# Altium schematic sheet led_clock-LEDDRV.SchDoc
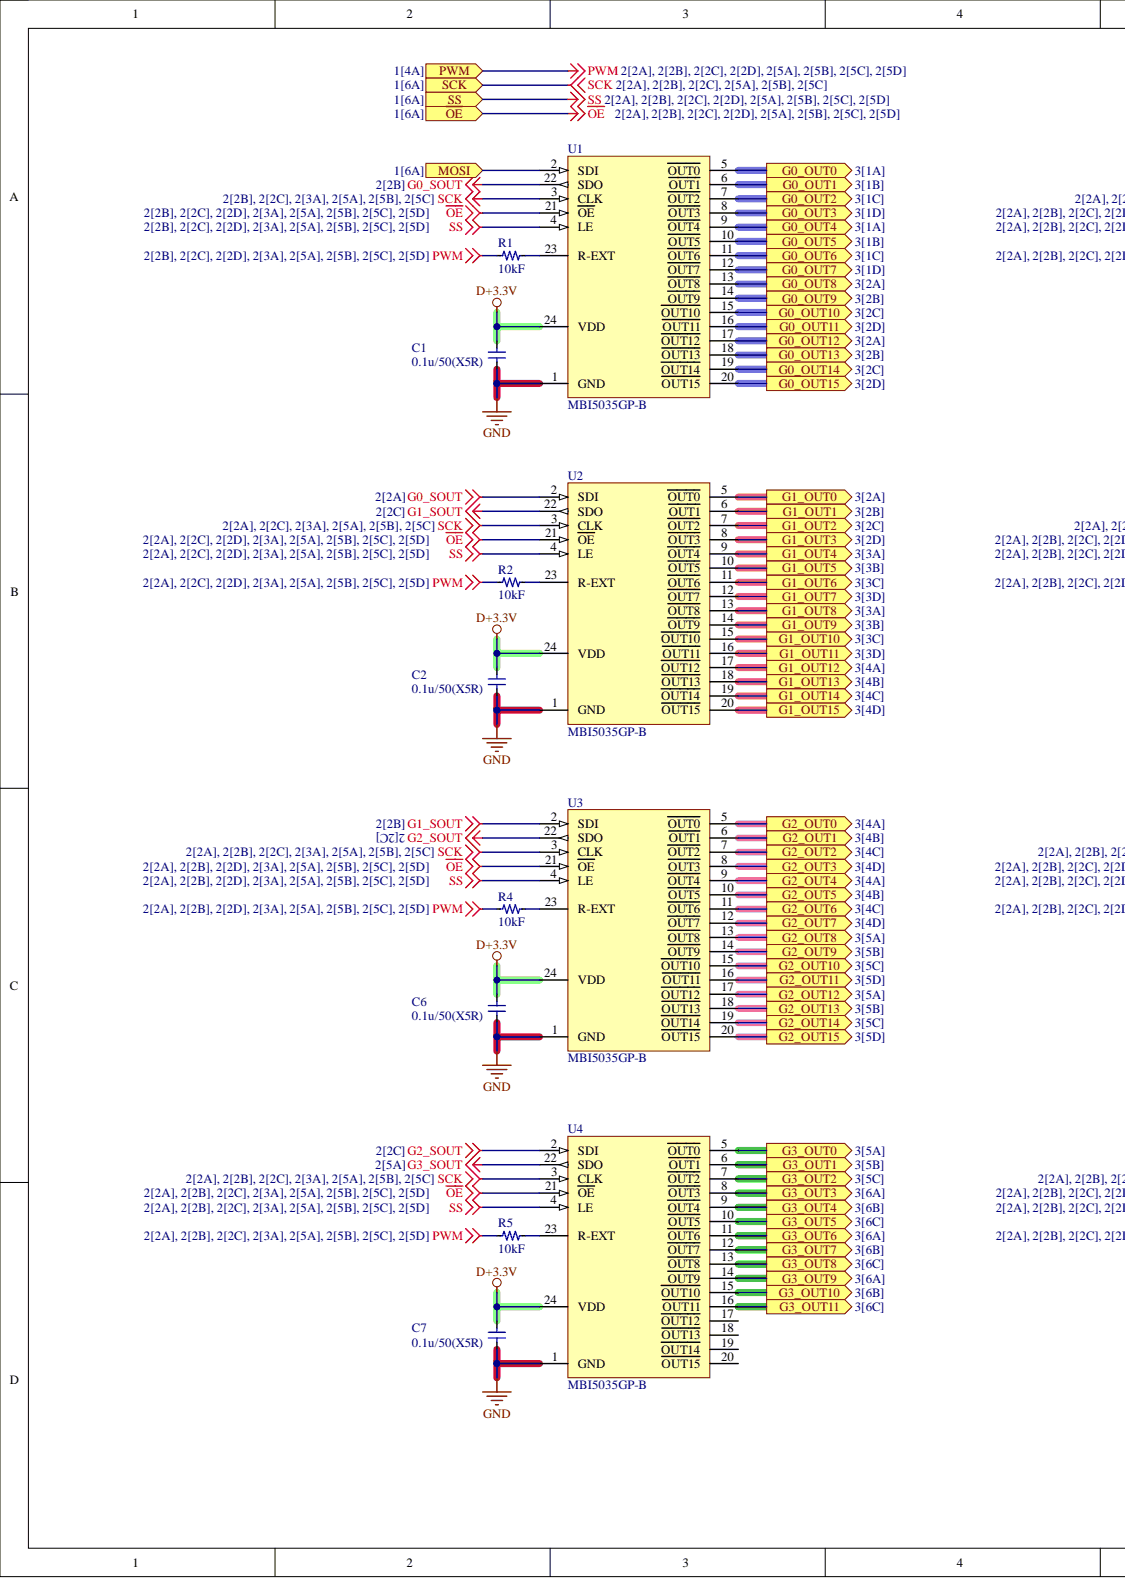
[diagram p0: led_clock-LEDDRV.SchDoc, left]
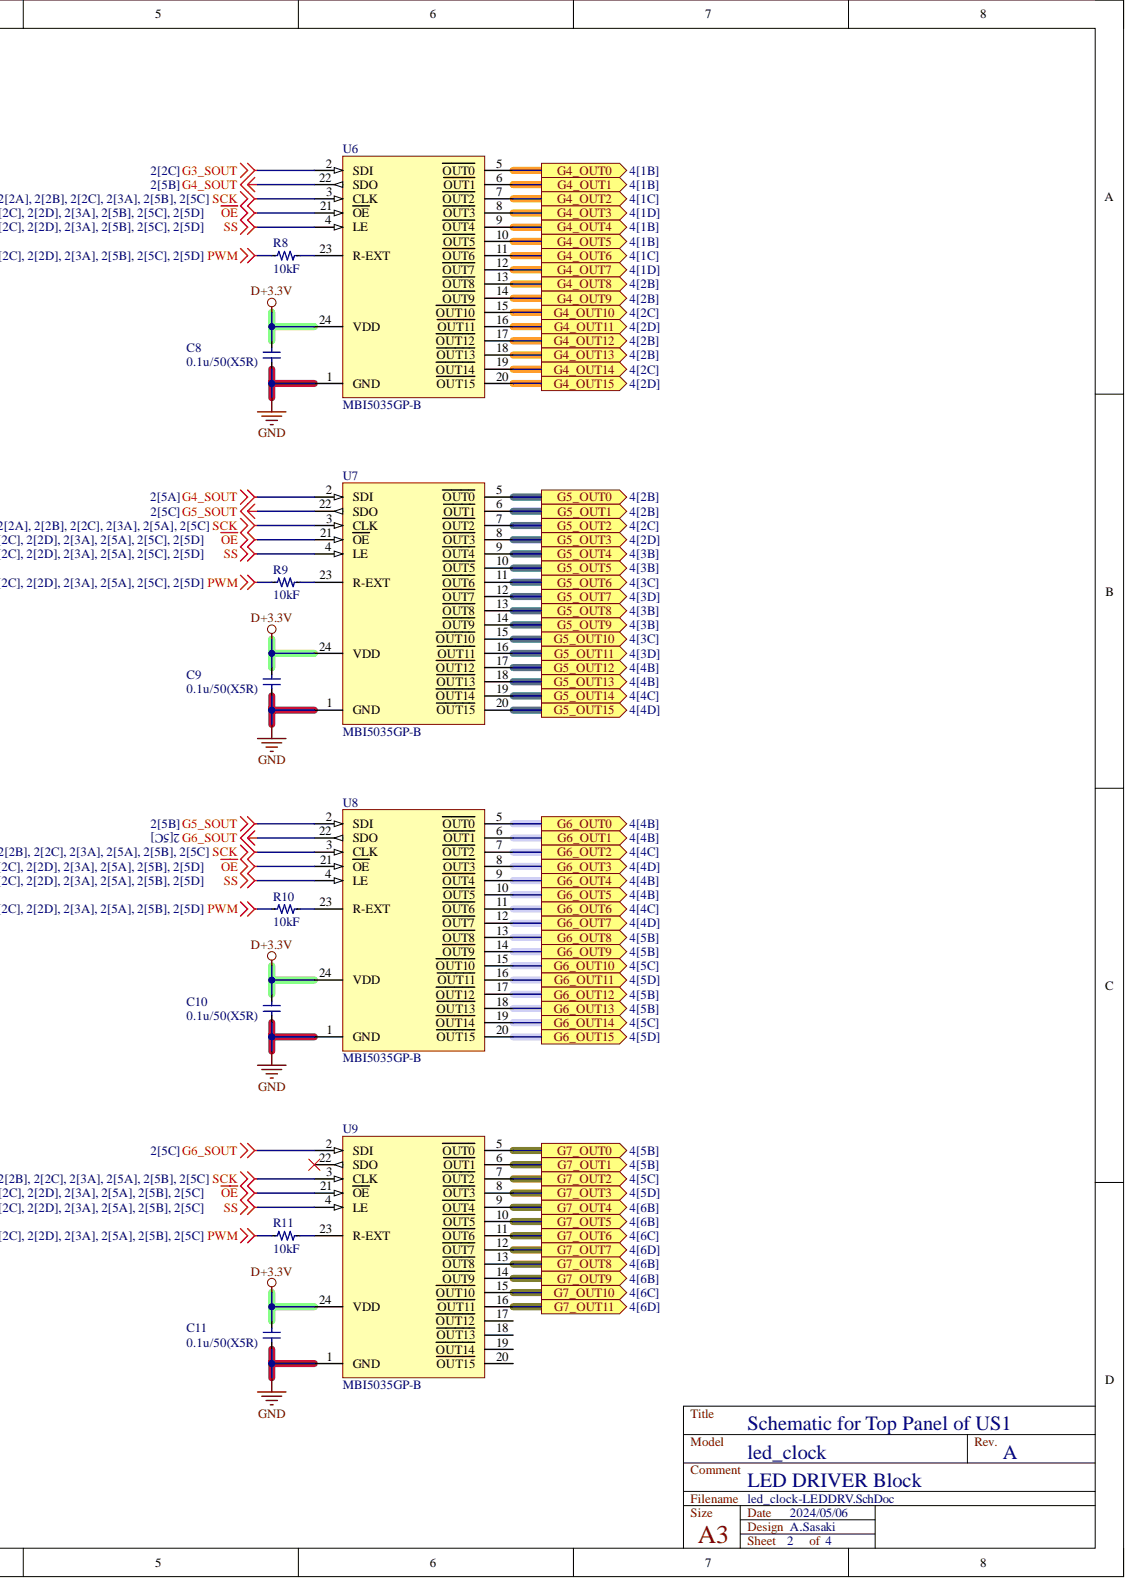
[diagram p1: led_clock-LEDDRV.SchDoc, right]

## Parts
C1  0.1u/50(X5R)  [C-METRIC-1005]
C2  0.1u/50(X5R)  [C-METRIC-1005]
C6  0.1u/50(X5R)  [C-METRIC-1005]
C7  0.1u/50(X5R)  [C-METRIC-1005]
C8  0.1u/50(X5R)  [C-METRIC-1005]
C9  0.1u/50(X5R)  [C-METRIC-1005]
C10  0.1u/50(X5R)  [C-METRIC-1005]
C11  0.1u/50(X5R)  [C-METRIC-1005]
R1  10kF  [R-METRIC-1005]
R2  10kF  [R-METRIC-1005]
R4  10kF  [R-METRIC-1005]
R5  10kF  [R-METRIC-1005]
R8  10kF  [R-METRIC-1005]
R9  10kF  [R-METRIC-1005]
R10  10kF  [R-METRIC-1005]
R11  10kF  [R-METRIC-1005]
U1  MBI5035GP-B  [SSOP24L-150-0.64_SOP64P600X175-24N]
U2  MBI5035GP-B  [SSOP24L-150-0.64_SOP64P600X175-24N]
U3  MBI5035GP-B  [SSOP24L-150-0.64_SOP64P600X175-24N]
U4  MBI5035GP-B  [SSOP24L-150-0.64_SOP64P600X175-24N]
U6  MBI5035GP-B  [SSOP24L-150-0.64_SOP64P600X175-24N]
U7  MBI5035GP-B  [SSOP24L-150-0.64_SOP64P600X175-24N]
U8  MBI5035GP-B  [SSOP24L-150-0.64_SOP64P600X175-24N]
U9  MBI5035GP-B  [SSOP24L-150-0.64_SOP64P600X175-24N]

## Ports
G0_OUT0, G0_OUT1, G0_OUT2, G0_OUT3, G0_OUT4, G0_OUT5, G0_OUT6, G0_OUT7, G0_OUT8, G0_OUT9, G0_OUT10, G0_OUT11, G0_OUT12, G0_OUT13, G0_OUT14, G0_OUT15, G1_OUT0, G1_OUT1, G1_OUT2, G1_OUT3, G1_OUT4, G1_OUT5, G1_OUT6, G1_OUT7, G1_OUT8, G1_OUT9, G1_OUT10, G1_OUT11, G1_OUT12, G1_OUT13, G1_OUT14, G1_OUT15, G2_OUT0, G2_OUT1, G2_OUT2, G2_OUT3, G2_OUT4, G2_OUT5, G2_OUT6, G2_OUT7, G2_OUT8, G2_OUT9, G2_OUT10, G2_OUT11, G2_OUT12, G2_OUT13, G2_OUT14, G2_OUT15, G3_OUT0, G3_OUT1, G3_OUT2, G3_OUT3, G3_OUT4, G3_OUT5, G3_OUT6, G3_OUT7, G3_OUT8, G3_OUT9, G3_OUT10, G3_OUT11, G4_OUT0, G4_OUT1, G4_OUT2, G4_OUT3, G4_OUT4, G4_OUT5, G4_OUT6, G4_OUT7, G4_OUT8, G4_OUT9, G4_OUT10, G4_OUT11, G4_OUT12, G4_OUT13, G4_OUT14, G4_OUT15, G5_OUT0, G5_OUT1, G5_OUT2, G5_OUT3, G5_OUT4, G5_OUT5, G5_OUT6, G5_OUT7, G5_OUT8, G5_OUT9, G5_OUT10, G5_OUT11, G5_OUT12, G5_OUT13, G5_OUT14, G5_OUT15, G6_OUT0, G6_OUT1, G6_OUT2, G6_OUT3, G6_OUT4, G6_OUT5, G6_OUT6, G6_OUT7, G6_OUT8, G6_OUT9, G6_OUT10, G6_OUT11, G6_OUT12, G6_OUT13, G6_OUT14, G6_OUT15, G7_OUT0, G7_OUT1, G7_OUT2, G7_OUT3, G7_OUT4, G7_OUT5, G7_OUT6, G7_OUT7, G7_OUT8, G7_OUT9, G7_OUT10, G7_OUT11, MOSI, PWM, SCK, SS, ~{OE}

## Nets
D+3.3V: C1.1 C2.1 C6.1 C7.1 C8.1 C9.1 C10.1 C11.1 U1.24(VDD) U2.24(VDD) U3.24(VDD) U4.24(VDD) U6.24(VDD) U7.24(VDD) U8.24(VDD) U9.24(VDD)
G0_SOUT: U1.22(SDO) U2.2(SDI)
G1_SOUT: U2.22(SDO) U3.2(SDI)
G2_SOUT: U3.22(SDO) U4.2(SDI)
G3_SOUT: U4.22(SDO) U6.2(SDI)
G4_SOUT: U6.22(SDO) U7.2(SDI)
G5_SOUT: U7.22(SDO) U8.2(SDI)
G6_SOUT: U8.22(SDO) U9.2(SDI)
GND: C1.2 C2.2 C6.2 C7.2 C8.2 C9.2 C10.2 C11.2 U1.1(GND) U2.1(GND) U3.1(GND) U4.1(GND) U6.1(GND) U7.1(GND) U8.1(GND) U9.1(GND)
G0_OUT0: U1.5(OUT0) ; port G0_OUT0
G0_OUT1: U1.6(OUT1) ; port G0_OUT1
G0_OUT2: U1.7(OUT2) ; port G0_OUT2
G0_OUT3: U1.8(OUT3) ; port G0_OUT3
G0_OUT4: U1.9(OUT4) ; port G0_OUT4
G0_OUT5: U1.10(OUT5) ; port G0_OUT5
G0_OUT6: U1.11(OUT6) ; port G0_OUT6
G0_OUT7: U1.12(OUT7) ; port G0_OUT7
G0_OUT8: U1.13(OUT8) ; port G0_OUT8
G0_OUT9: U1.14(OUT9) ; port G0_OUT9
G0_OUT10: U1.15(OUT10) ; port G0_OUT10
G0_OUT11: U1.16(OUT11) ; port G0_OUT11
G0_OUT12: U1.17(OUT12) ; port G0_OUT12
G0_OUT13: U1.18(OUT13) ; port G0_OUT13
G0_OUT14: U1.19(OUT14) ; port G0_OUT14
G0_OUT15: U1.20(OUT15) ; port G0_OUT15
G1_OUT0: U2.5(OUT0) ; port G1_OUT0
G1_OUT1: U2.6(OUT1) ; port G1_OUT1
G1_OUT2: U2.7(OUT2) ; port G1_OUT2
G1_OUT3: U2.8(OUT3) ; port G1_OUT3
G1_OUT4: U2.9(OUT4) ; port G1_OUT4
G1_OUT5: U2.10(OUT5) ; port G1_OUT5
G1_OUT6: U2.11(OUT6) ; port G1_OUT6
G1_OUT7: U2.12(OUT7) ; port G1_OUT7
G1_OUT8: U2.13(OUT8) ; port G1_OUT8
G1_OUT9: U2.14(OUT9) ; port G1_OUT9
G1_OUT10: U2.15(OUT10) ; port G1_OUT10
G1_OUT11: U2.16(OUT11) ; port G1_OUT11
G1_OUT12: U2.17(OUT12) ; port G1_OUT12
G1_OUT13: U2.18(OUT13) ; port G1_OUT13
G1_OUT14: U2.19(OUT14) ; port G1_OUT14
G1_OUT15: U2.20(OUT15) ; port G1_OUT15
G2_OUT0: U3.5(OUT0) ; port G2_OUT0
G2_OUT1: U3.6(OUT1) ; port G2_OUT1
G2_OUT2: U3.7(OUT2) ; port G2_OUT2
G2_OUT3: U3.8(OUT3) ; port G2_OUT3
G2_OUT4: U3.9(OUT4) ; port G2_OUT4
G2_OUT5: U3.10(OUT5) ; port G2_OUT5
G2_OUT6: U3.11(OUT6) ; port G2_OUT6
G2_OUT7: U3.12(OUT7) ; port G2_OUT7
G2_OUT8: U3.13(OUT8) ; port G2_OUT8
G2_OUT9: U3.14(OUT9) ; port G2_OUT9
G2_OUT10: U3.15(OUT10) ; port G2_OUT10
G2_OUT11: U3.16(OUT11) ; port G2_OUT11
G2_OUT12: U3.17(OUT12) ; port G2_OUT12
G2_OUT13: U3.18(OUT13) ; port G2_OUT13
G2_OUT14: U3.19(OUT14) ; port G2_OUT14
G2_OUT15: U3.20(OUT15) ; port G2_OUT15
G3_OUT0: U4.5(OUT0) ; port G3_OUT0
G3_OUT1: U4.6(OUT1) ; port G3_OUT1
G3_OUT2: U4.7(OUT2) ; port G3_OUT2
G3_OUT3: U4.8(OUT3) ; port G3_OUT3
G3_OUT4: U4.9(OUT4) ; port G3_OUT4
G3_OUT5: U4.10(OUT5) ; port G3_OUT5
G3_OUT6: U4.11(OUT6) ; port G3_OUT6
G3_OUT7: U4.12(OUT7) ; port G3_OUT7
G3_OUT8: U4.13(OUT8) ; port G3_OUT8
G3_OUT9: U4.14(OUT9) ; port G3_OUT9
G3_OUT10: U4.15(OUT10) ; port G3_OUT10
G3_OUT11: U4.16(OUT11) ; port G3_OUT11
G4_OUT0: U6.5(OUT0) ; port G4_OUT0
G4_OUT1: U6.6(OUT1) ; port G4_OUT1
G4_OUT2: U6.7(OUT2) ; port G4_OUT2
G4_OUT3: U6.8(OUT3) ; port G4_OUT3
G4_OUT4: U6.9(OUT4) ; port G4_OUT4
G4_OUT5: U6.10(OUT5) ; port G4_OUT5
G4_OUT6: U6.11(OUT6) ; port G4_OUT6
G4_OUT7: U6.12(OUT7) ; port G4_OUT7
G4_OUT8: U6.13(OUT8) ; port G4_OUT8
G4_OUT9: U6.14(OUT9) ; port G4_OUT9
G4_OUT10: U6.15(OUT10) ; port G4_OUT10
G4_OUT11: U6.16(OUT11) ; port G4_OUT11
G4_OUT12: U6.17(OUT12) ; port G4_OUT12
G4_OUT13: U6.18(OUT13) ; port G4_OUT13
G4_OUT14: U6.19(OUT14) ; port G4_OUT14
G4_OUT15: U6.20(OUT15) ; port G4_OUT15
G5_OUT0: U7.5(OUT0) ; port G5_OUT0
G5_OUT1: U7.6(OUT1) ; port G5_OUT1
G5_OUT2: U7.7(OUT2) ; port G5_OUT2
G5_OUT3: U7.8(OUT3) ; port G5_OUT3
G5_OUT4: U7.9(OUT4) ; port G5_OUT4
G5_OUT5: U7.10(OUT5) ; port G5_OUT5
G5_OUT6: U7.11(OUT6) ; port G5_OUT6
G5_OUT7: U7.12(OUT7) ; port G5_OUT7
G5_OUT8: U7.13(OUT8) ; port G5_OUT8
G5_OUT9: U7.14(OUT9) ; port G5_OUT9
G5_OUT10: U7.15(OUT10) ; port G5_OUT10
G5_OUT11: U7.16(OUT11) ; port G5_OUT11
G5_OUT12: U7.17(OUT12) ; port G5_OUT12
G5_OUT13: U7.18(OUT13) ; port G5_OUT13
G5_OUT14: U7.19(OUT14) ; port G5_OUT14
G5_OUT15: U7.20(OUT15) ; port G5_OUT15
G6_OUT0: U8.5(OUT0) ; port G6_OUT0
G6_OUT1: U8.6(OUT1) ; port G6_OUT1
G6_OUT2: U8.7(OUT2) ; port G6_OUT2
G6_OUT3: U8.8(OUT3) ; port G6_OUT3
G6_OUT4: U8.9(OUT4) ; port G6_OUT4
G6_OUT5: U8.10(OUT5) ; port G6_OUT5
G6_OUT6: U8.11(OUT6) ; port G6_OUT6
G6_OUT7: U8.12(OUT7) ; port G6_OUT7
G6_OUT8: U8.13(OUT8) ; port G6_OUT8
G6_OUT9: U8.14(OUT9) ; port G6_OUT9
G6_OUT10: U8.15(OUT10) ; port G6_OUT10
G6_OUT11: U8.16(OUT11) ; port G6_OUT11
G6_OUT12: U8.17(OUT12) ; port G6_OUT12
G6_OUT13: U8.18(OUT13) ; port G6_OUT13
G6_OUT14: U8.19(OUT14) ; port G6_OUT14
G6_OUT15: U8.20(OUT15) ; port G6_OUT15
G7_OUT0: U9.5(OUT0) ; port G7_OUT0
G7_OUT1: U9.6(OUT1) ; port G7_OUT1
G7_OUT2: U9.7(OUT2) ; port G7_OUT2
G7_OUT3: U9.8(OUT3) ; port G7_OUT3
G7_OUT4: U9.9(OUT4) ; port G7_OUT4
G7_OUT5: U9.10(OUT5) ; port G7_OUT5
G7_OUT6: U9.11(OUT6) ; port G7_OUT6
G7_OUT7: U9.12(OUT7) ; port G7_OUT7
G7_OUT8: U9.13(OUT8) ; port G7_OUT8
G7_OUT9: U9.14(OUT9) ; port G7_OUT9
G7_OUT10: U9.15(OUT10) ; port G7_OUT10
G7_OUT11: U9.16(OUT11) ; port G7_OUT11
MOSI: U1.2(SDI) ; port MOSI
~{OE}: U1.21(OE) U2.21(OE) U3.21(OE) U4.21(OE) U6.21(OE) U7.21(OE) U8.21(OE) U9.21(OE) ; port ~{OE}
PWM: R1.1 R2.1 R4.1 R5.1 R8.1 R9.1 R10.1 R11.1 ; port PWM
SCK: U1.3(CLK) U2.3(CLK) U3.3(CLK) U4.3(CLK) U6.3(CLK) U7.3(CLK) U8.3(CLK) U9.3(CLK) ; port SCK
SS: U1.4(LE) U2.4(LE) U3.4(LE) U4.4(LE) U6.4(LE) U7.4(LE) U8.4(LE) U9.4(LE) ; port SS
NetR1_2: R1.2 U1.23(R-EXT)
NetR2_2: R2.2 U2.23(R-EXT)
NetR4_2: R4.2 U3.23(R-EXT)
NetR5_2: R5.2 U4.23(R-EXT)
NetR8_2: R8.2 U6.23(R-EXT)
NetR9_2: R9.2 U7.23(R-EXT)
NetR10_2: R10.2 U8.23(R-EXT)
NetR11_2: R11.2 U9.23(R-EXT)

Not connected: U4 17(OUT12) 18(OUT13) 19(OUT14) 20(OUT15); U9 17(OUT12) 18(OUT13) 19(OUT14) 20(OUT15) 22(SDO)

## Library data of the parts
C1 C2 C6-C11 = CL05B104KB54PNC: CAP CER 0.1UF 50V 10% X7R 0402(1005metric); Manufacturer: Samsung Electro-Mechanics; Value: 0.1u; Component Kind: Standard; LCSC Part Number: C307331; Library Name: Samsung.IntLib; Manufacturer Part Number: CL05B104KB54PNC; Simulation: CAP; Size: 1005; Supplier 1: Digi-Key; Supplier 2: Digi-Key; Supplier 3: Digi-Key; Supplier 4: mouser; Supplier Part Number 1: 1276-CL05B104KB54PNCTR-ND; Supplier Part Number 2: 1276-CL05B104KB54PNCCT-ND; Supplier Part Number 3: 1276-CL05B104KB54PNCDKR-ND; Supplier Part Number 4: 187-CL05B104KB54PNC; ValueDisp: 0.1u/50(X5R)
R1 R2 R4 R5 R8-R11 = 0402WGF1002TCE: RES SMD 10K OHM 1% 1/16W 0402; Manufacturer: Uniroyal Elec; Substitute: enable; Component Kind: Standard; LCSC Part Number: C25744; Library Name: Uniroyal.IntLib; Manufacturer Part Number: 0402WGF1002TCE; Simulation: RESISTOR; Size: 1005; Supplier 1: Digi-Key; Supplier 2: Digi-Key; Supplier 3: Digi-Key; Supplier 4: mouser; Value: 10k; Value_disp: 10kF
U1-U4 U6-U9 = MBI5035GP-B: IC DRV LED 16CH PARALLEL SSOP24; Manufacturer: MBI(Macroblock, Inc.); Component Kind: Standard; Description 1: MBI5035GP-B; Description 2: IC DRV LED 16CH PARALLEL SSOP24; FirstPublished: 2024/05/02; LCSC Part Number: C261130; Library Name: MBI.IntLib; Manufacturer Part Number: MBI5035GP-B; Supplier 1: Digi-Key; Supplier 2: Digi-Key; Supplier 3: Digi-Key; Supplier 4: MOUSER; Publisher: Atsushi Sasaki
